# Altium schematic sheet nav teensy.SchDoc("nav teensy")
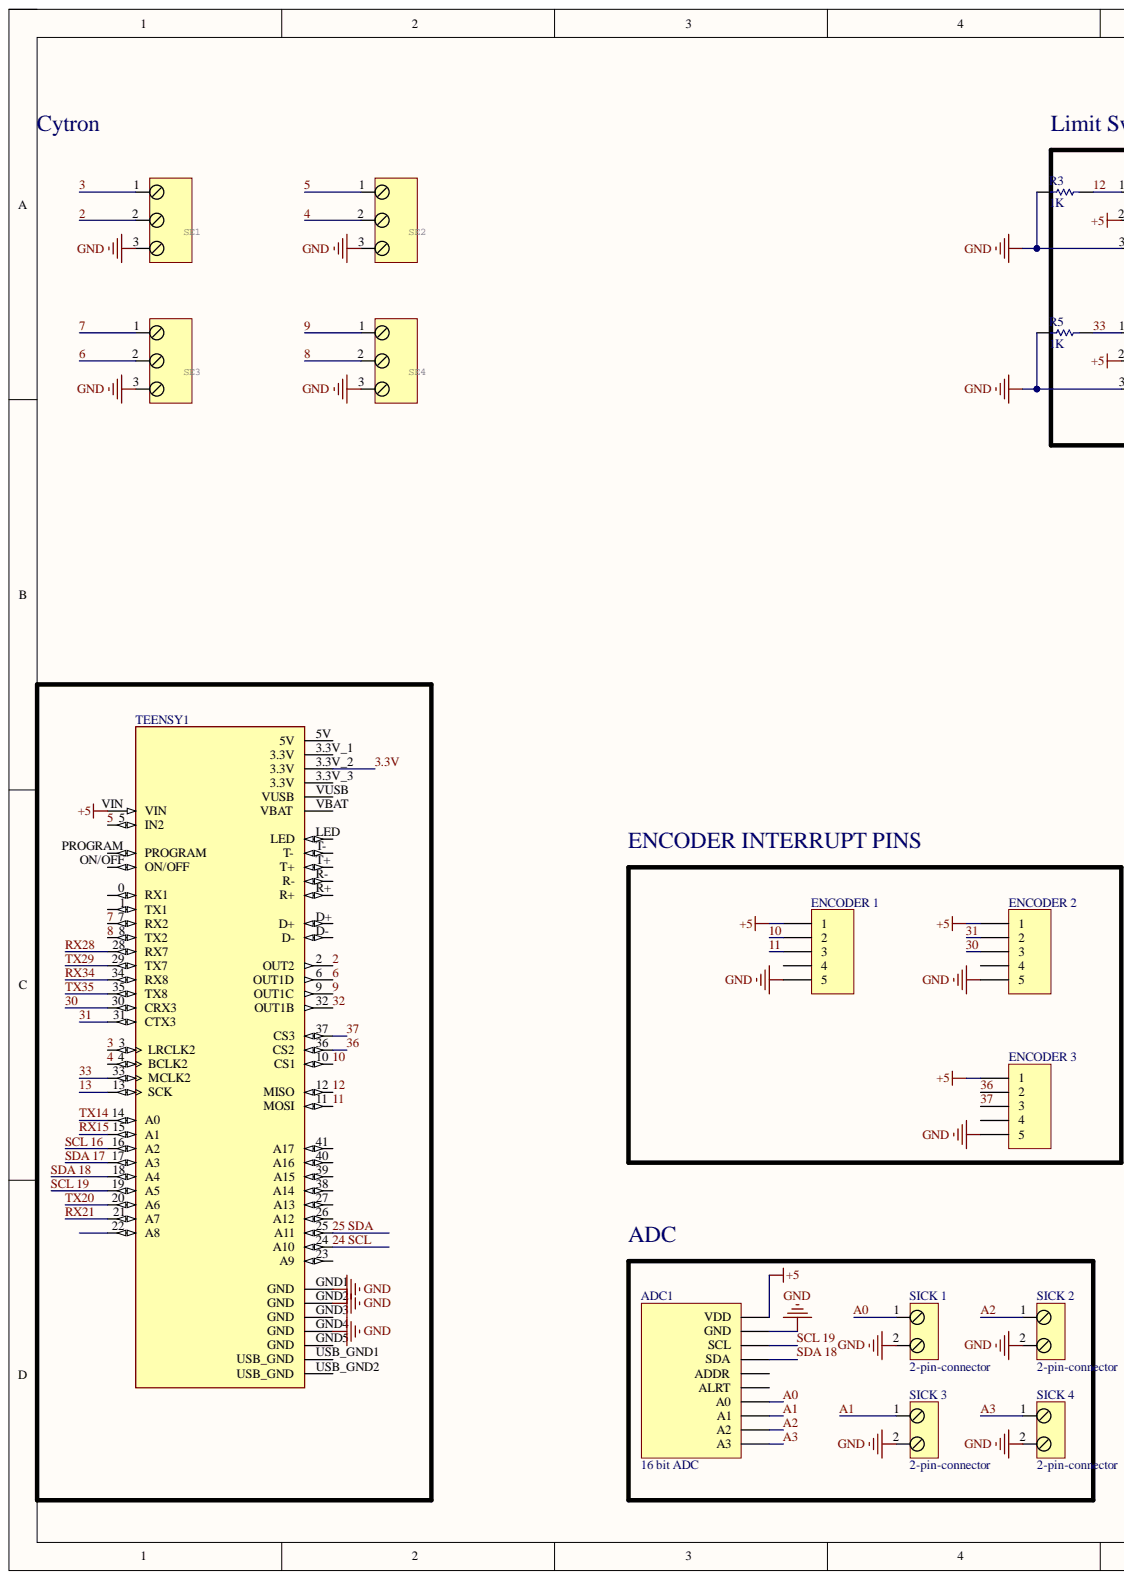
[diagram p0: nav teensy.SchDoc, left]
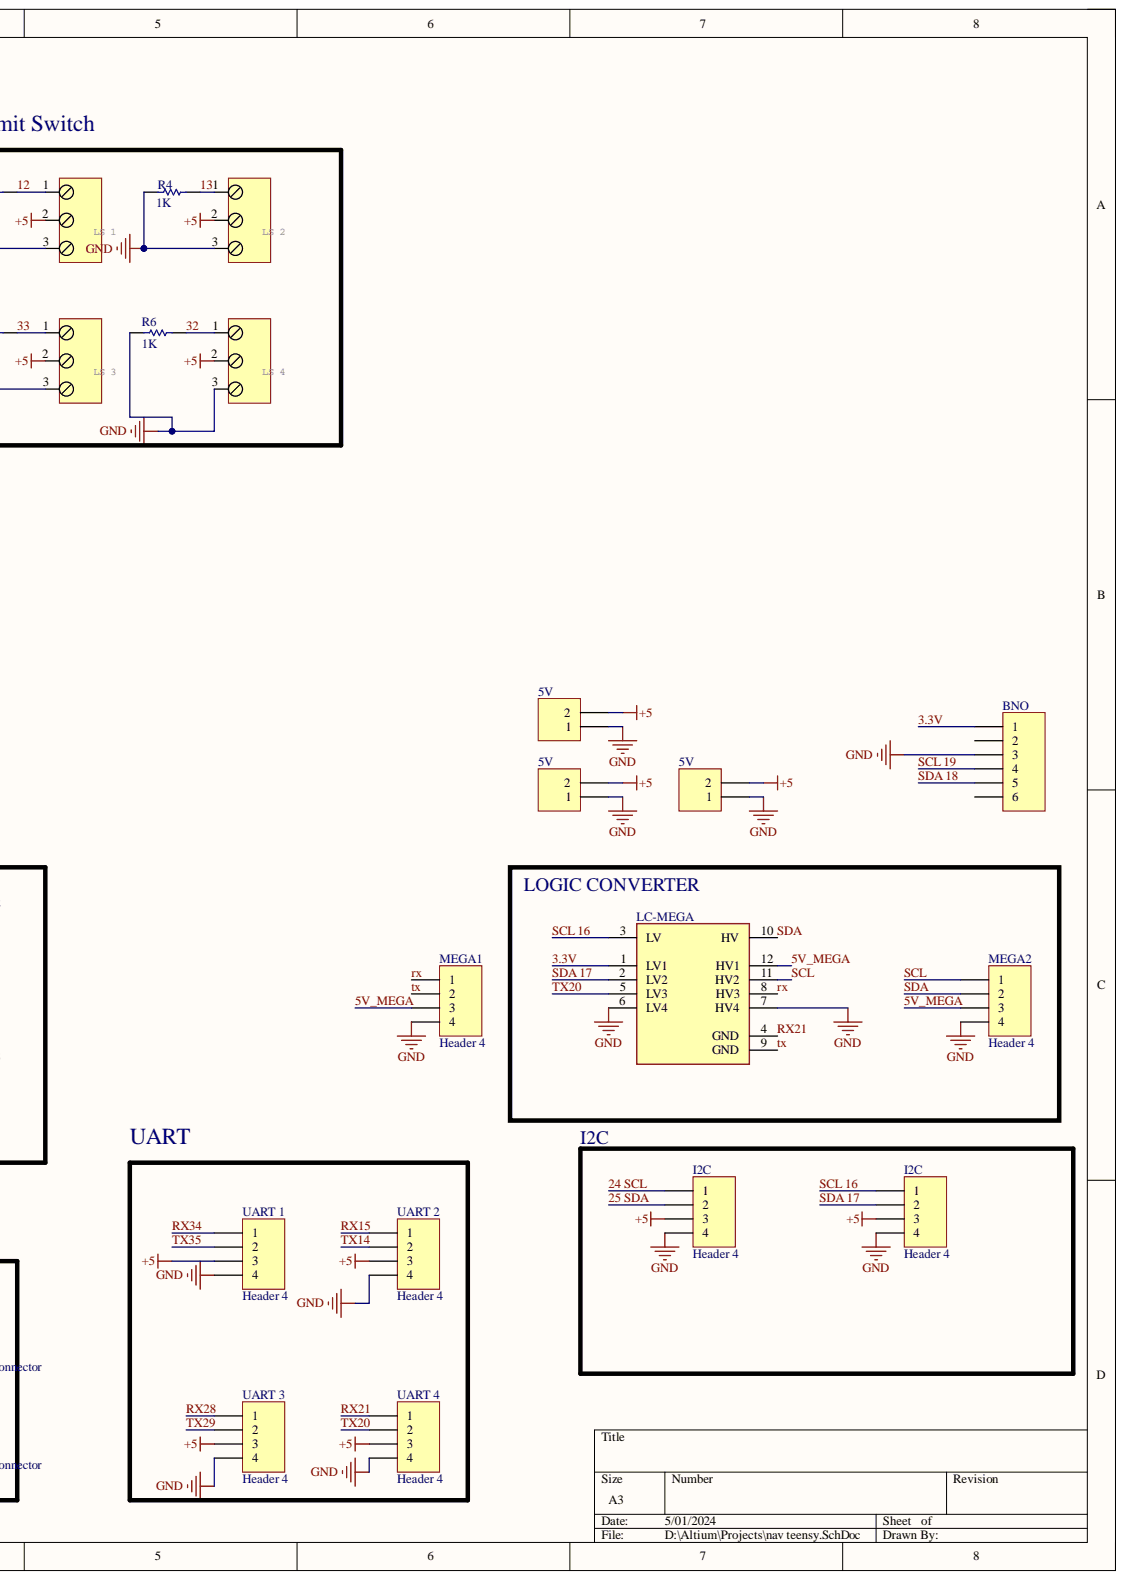
[diagram p1: nav teensy.SchDoc, right]

## Parts
5V  Header 2H  [HDR1X2H]  (value not drawn)
5V  Header 2H  [HDR1X2H]  (value not drawn)
5V  Header 2H  [HDR1X2H]  (value not drawn)
ADC1  16 bit ADC  [16 bit ADC]
BNO  Header 6  [HDR1X6]  (value not drawn)
ENCODER_1  Header 5  [HDR1X5]  (value not drawn)
ENCODER_2  Header 5  [HDR1X5]  (value not drawn)
ENCODER_3  Header 5  [HDR1X5]  (value not drawn)
I2C  Header 4  [HDR1X4]
I2C  Header 4  [HDR1X4]
LC-MEGA  BOB-12009  [FP-BOB-12009-MFG]  (value not drawn)
LS_1  PHOENIX CONNECTOR  [HDR1X3]  (value not drawn)
LS_2  PHOENIX CONNECTOR  [HDR1X3]  (value not drawn)
LS_3  PHOENIX CONNECTOR  [HDR1X3]  (value not drawn)
LS_4  PHOENIX CONNECTOR  [HDR1X3]  (value not drawn)
MEGA1  Header 4  [HDR1X4]
MEGA2  Header 4  [HDR1X4]
R3  1K  [MY_(1w)_0613V]
R4  1K  [MY_(1w)_0613V]
R5  1K  [MY_(1w)_0613V]
R6  1K  [MY_(1w)_0613V]
SE1  PHOENIX CONNECTOR  [HDR1X3]  (value not drawn)
SE2  PHOENIX CONNECTOR  [HDR1X3]  (value not drawn)
SE3  PHOENIX CONNECTOR  [HDR1X3]  (value not drawn)
SE4  PHOENIX CONNECTOR  [HDR1X3]  (value not drawn)
SICK_1  2-pin-connector  [HDR1X2]
SICK_2  2-pin-connector  [HDR1X2]
SICK_3  2-pin-connector  [HDR1X2]
SICK_4  2-pin-connector  [HDR1X2]
TEENSY1  DEV-16771  [MODULE_DEV-16771]  (value not drawn)
UART_1  Header 4  [HDR1X4]
UART_2  Header 4  [HDR1X4]
UART_3  Header 4  [HDR1X4]
UART_4  Header 4  [HDR1X4]

## Nets
GND: 5V.1 ADC1.GND BNO.3 ENCODER_1.5 ENCODER_2.5 ENCODER_3.5 I2C.4 LC-MEGA.6(LV4) LC-MEGA.7(HV4) LS_1.3 LS_2.3 LS_3.3 LS_4.3 MEGA1.4 MEGA2.4 R3.1 R4.1 R5.1 R6.1 SE1.3 SE2.3 SE3.3 SE4.3 SICK_1.2 SICK_2.2 SICK_3.2 SICK_4.2 TEENSY1.GND1(GND) TEENSY1.GND2(GND) TEENSY1.GND4(GND) UART_1.4 UART_2.4 UART_3.4 UART_4.4
2: SE1.2 TEENSY1.2(OUT2)
3: SE1.1 TEENSY1.3(LRCLK2)
3.3V: BNO.1 LC-MEGA.1(LV1) TEENSY1.3.3V_2(3.3V)
4: SE2.2 TEENSY1.4(BCLK2)
5: 5V.2 ADC1.VDD ENCODER_1.1 ENCODER_2.1 ENCODER_3.1 I2C.3 LS_1.2 LS_2.2 LS_3.2 LS_4.2 SE2.1 TEENSY1.5(IN2) TEENSY1.VIN UART_1.3 UART_2.3 UART_3.3 UART_4.3
5V_MEGA: LC-MEGA.12(HV1) MEGA1.3 MEGA2.3
6: SE3.2 TEENSY1.6(OUT1D)
7: SE3.1 TEENSY1.7(RX2)
8: SE4.2 TEENSY1.8(TX2)
9: SE4.1 TEENSY1.9(OUT1C)
10: ENCODER_1.2 TEENSY1.10(CS1)
11: ENCODER_1.3 TEENSY1.11(MOSI)
12: LS_1.1 R3.2 TEENSY1.12(MISO)
13: LS_2.1 R4.2 TEENSY1.13(SCK)
24 SCL: I2C.1 TEENSY1.24(A10)
25 SDA: I2C.2 TEENSY1.25(A11)
30: ENCODER_2.3 TEENSY1.30(CRX3)
31: ENCODER_2.2 TEENSY1.31(CTX3)
32: LS_4.1 R6.2 TEENSY1.32(OUT1B)
33: LS_3.1 R5.2 TEENSY1.33(MCLK2)
36: ENCODER_3.2 TEENSY1.36(CS2)
37: ENCODER_3.3 TEENSY1.37(CS3)
A0: ADC1.A0 SICK_1.1
A1: ADC1.A1 SICK_3.1
A2: ADC1.A2 SICK_2.1
A3: ADC1.A3 SICK_4.1
rx: LC-MEGA.8(HV3) MEGA1.1
RX15: TEENSY1.15(A1) UART_2.1
RX21: LC-MEGA.4(GND) TEENSY1.21(A7) UART_4.1
RX28: TEENSY1.28(RX7) UART_3.1
RX34: TEENSY1.34(RX8) UART_1.1
SCL: LC-MEGA.11(HV2) MEGA2.1
SCL 16: I2C.1 LC-MEGA.3(LV) TEENSY1.16(A2)
SCL 19: ADC1.SCL BNO.4 TEENSY1.19(A5)
SDA: LC-MEGA.10(HV) MEGA2.2
SDA 17: I2C.2 LC-MEGA.2(LV2) TEENSY1.17(A3)
SDA 18: ADC1.SDA BNO.5 TEENSY1.18(A4)
tx: LC-MEGA.9(GND) MEGA1.2
TX14: TEENSY1.14(A0) UART_2.2
TX20: LC-MEGA.5(LV3) TEENSY1.20(A6) UART_4.2
TX29: TEENSY1.29(TX7) UART_3.2
TX35: TEENSY1.35(TX8) UART_1.2

Not connected: ADC1 ADDR ALRT; BNO 2 6; ENCODER_1 4; ENCODER_2 4; ENCODER_3 4; TEENSY1 0(RX1) 1(TX1) 3.3V_1(3.3V) 3.3V_3(3.3V) 5V 22(A8) 23(A9) 26(A12) 27(A13) 38(A14) 39(A15) 40(A16) 41(A17) D+ D- GND3(GND) GND5(GND) LED ON/OFF PROGRAM R+ R- T+ T- USB_GND1(USB_GND) USB_GND2(USB_GND) VBAT VUSB

## Library data of the parts
5V 5V 5V = Header 2H: Header, 2-Pin, Right Angle; Component Kind: Standard; LatestRevisionDate: 17-Jul-2002; LatestRevisionNote: Re-released for DXP Platform.; Library Name: Miscellaneous Connectors.IntLib; Publisher: Altium Limited
ADC1 = CMP-010-000000-1: Component Kind: Standard; Design Item ID: 16 bit ADC; Library Name: Team Automatons; Source: Team Automatons NEW.SCHLIB
BNO = CMP-022-000108-1: Header, 6-Pin; Component Kind: Standard; Component Type: Standard; Design Item ID: Header 6; LatestRevisionDate: 17-Jul-2002; LatestRevisionNote: Re-released for DXP Platform.; Pin Count: 20; Signal Integrity: Connector; Source: Miscellaneous Connectors.SchLib; Library Name: Team Automatons; Publisher: Altium Limited
ENCODER_1-ENCODER_3 = Header 5: Header, 5-Pin; Component Kind: Standard; LatestRevisionDate: 17-Jul-2002; LatestRevisionNote: Re-released for DXP Platform.; Library Name: Miscellaneous Connectors.IntLib; Publisher: Altium Limited
I2C I2C MEGA1 MEGA2 UART_1-UART_4 = Header 4: Header, 4-Pin; Component Kind: Standard; LatestRevisionDate: 17-Jul-2002; LatestRevisionNote: Re-released for DXP Platform.; Library Name: Miscellaneous Connectors.IntLib; Publisher: Altium Limited
LC-MEGA = CMP-157458-000001-1: LOGIC LEVEL CONVERTER - BI-DIREC; Logic Function: Translator; Component Kind: Standard; Library Name: Altium Content Vault
LS_1-LS_4 SE1-SE4 = 3-PIN-CONNECTOR: GateName_3: -3; SymbolName_3: SKBV-1; Component Kind: Standard; DeviceSetName: 3-PIN-CONNECTOR; OC_FARNELL: unknown; OC_NEWARK: unknown; Package: 3-PIN-CONNECTOR; Library Name: Team Automatons NEW.SCHLIB; LibraryName: Team Automatons (1)
R3-R6 = Res: Standard resistor; ASiD Part Number: Res-AS; Component Kind: Standard; Library Name: Mylib+Rev+4.IntLib; Value: 1K
TEENSY1 = CMP-010-000002-1: RT1062 Teensy 4.1 series ARMÂ® CortexÂ®-M7 MPU Embedded Evaluation Board; MF: SparkFun Electronics; Availability: In Stock; Check_prices: https://www.snapeda.com/parts/DEV-16771/SparkFun+Electronics/view-part/?ref=eda; Component Kind: Standard; Component Type: Standard; Design Item ID: DEV-16771; MP: DEV-16771; Package: None; Pin Count: 65; Price: None; Purchase-URL: https://pricing.snapeda.com/search/part/DEV-16771/?ref=eda; SnapEDA_Link: https://www.snapeda.com/parts/DEV-16771/SparkFun+Electronics/view-part/?ref=snap; Source: DEV-16771.SchLib; Library Name: Team Automatons
SICK_1-SICK_4 = 2-pin-connector: Component Kind: Standard; Library Name: Team Automatons NEW.SCHLIB
